# Altium schematic sheet Energy Harvesting.SchDoc("Energy Harvesting")
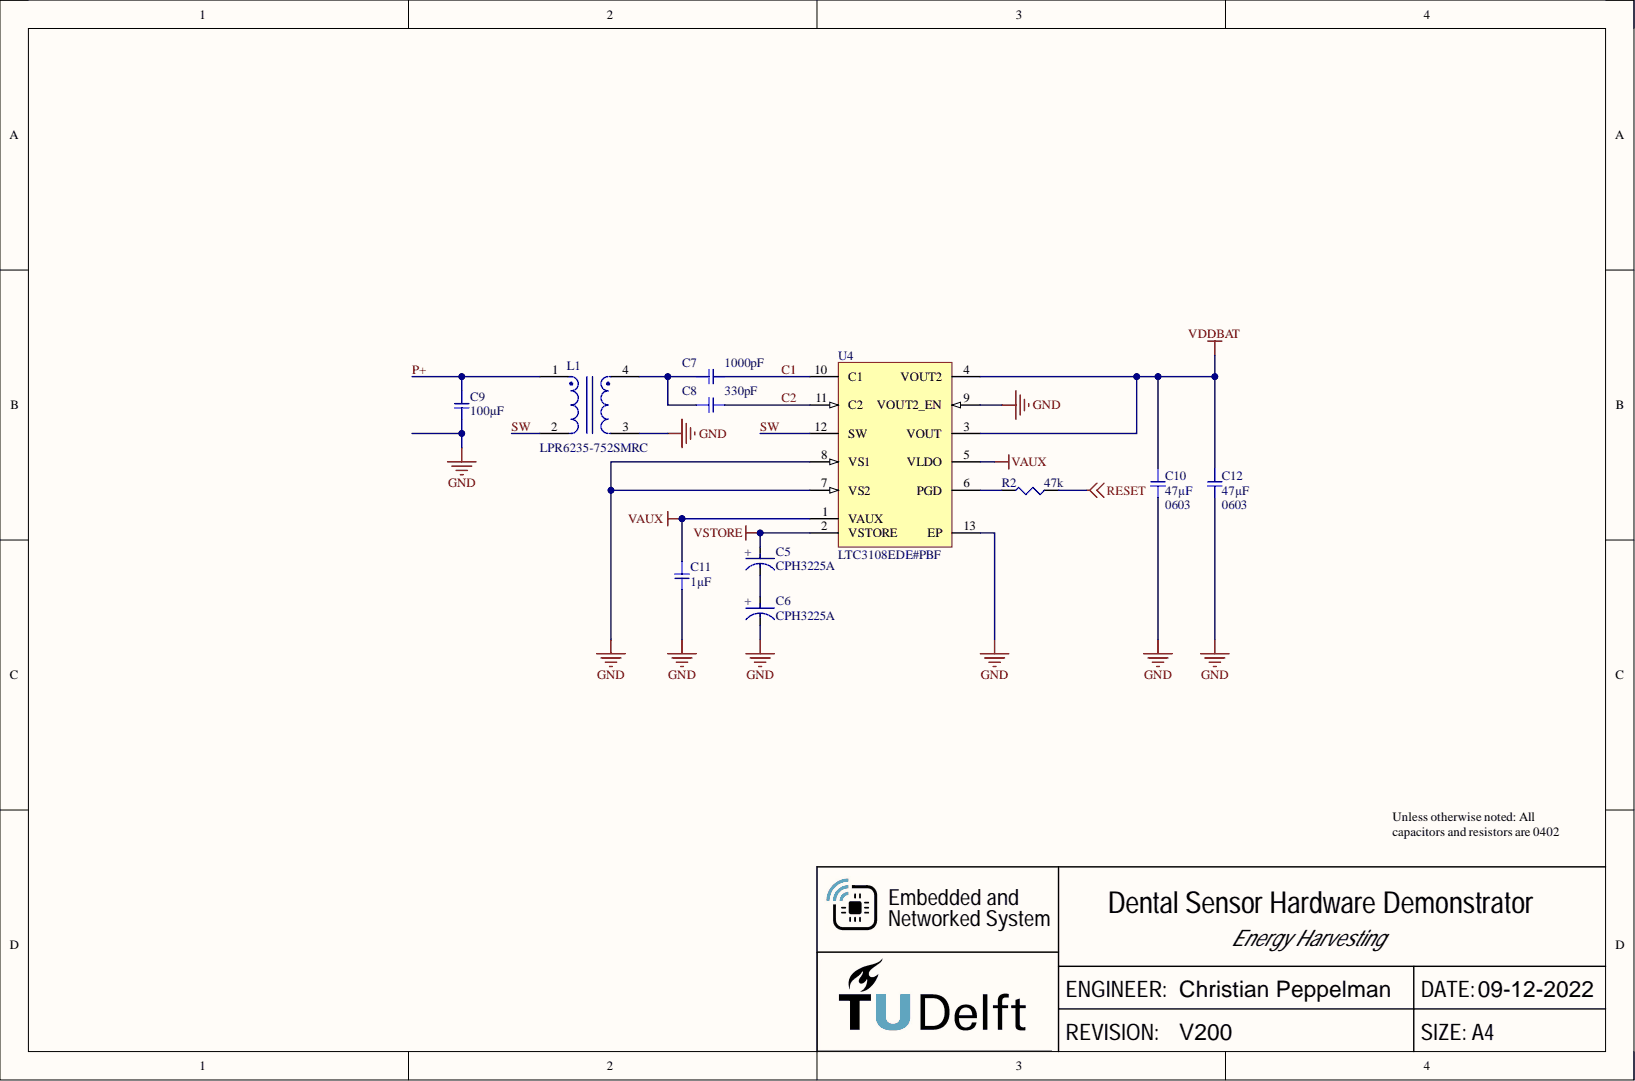
[diagram p0: Energy Harvesting.SchDoc]

## Parts
C5  CPH3225A  [CPH3225A]
C6  CPH3225A  [CPH3225A]
C7  1000pF  [CAPC1005X03N]
C8  330pF  [CAPC1005X03N]
C9  100µF  [CAPC2012X12N]
C10  47µF  Package / Case: 0603  [CAPC1608X12N]
C11  1µF  [CAPC1005X03N]
C12  47µF  Package / Case: 0603  [CAPC1608X12N]
L1  LPR6235-752SMRC  [LPR6235]
R2  47k  [CAPC1005X03N]
U4  LTC3108EDE#PBF  [LT-DE-12-05-08-1708_V]

## Nets
GND: C6.2 C9.2 C10.2 C11.2 C12.2 L1.3 U4.7(VS2) U4.8(VS1) U4.9(VOUT2_EN) U4.13(EP)
RESET: R2.1
VAUX: C11.1 U4.1(VAUX) U4.5(VLDO)
VDDBAT: C10.1 C12.1 U4.3(VOUT) U4.4(VOUT2)
VSTORE: C5.1 U4.2(VSTORE)
C1: C7.2 U4.10(C1)
C2: C8.2 U4.11(C2)
P+: C9.1 L1.1
SW: L1.2 U4.12(SW)
NetC5_2: C5.2 C6.1
NetC7_1: C7.1 C8.1 L1.4
NetR2_2: R2.2 U4.6(PGD)

## Library data of the parts
C5 C6 = CPH3225A: Supercapacitors / Ultracapacitors Reflow EDLC 3.3V, 11mF, 160 Ohm; Component Kind: Standard; Library Name: Library.SchLib
C7 = GRM155R61C102KA01D: CAP CER 1000PF 16V X5R 0402; Manufacturer: Murata Electronics North America; @ qty: 0; Minimum Quantity: 1; Tolerance: ±10%; Unit Price (USD): 0.1; Applications: General Purpose; Component Kind: Standard; Datasheets: http://www.murata.com/~/media/webrenewal/support/library/catalog/products/capacitor/mlcc/c02e.pdf; Digi-Key Part Number: 490-6308-1-ND; Factory Stock: 0; Features: -; Height - Seated (Max): -; Image: //media.digikey.com/Renders/Murata%20Renders/ZRB-SERIES-0402.jpg; Lead Spacing: -; Library Name: capacitor_murata_0402.IntLib; Mounting Type: Surface Mount, MLCC; Operating Temperature: -55°C ~ 85°C; Package / Case: 0402 (1005 Metric); Packaging: Cut Tape (CT); Part Number: GRM155R61C102KA01D; Part Status: Active; Quantity Available: 57479; Ratings: -; Series: GRM; Size / Dimension: 0.039" L x 0.020" W (1.00mm x 0.50mm); Temperature Coefficient: X5R; Thickness (Max): 0.022" (0.55mm); Value: 1000pF; Voltage: 16V
C8 = GRM155R71H331KA01J: CAP CER 330PF 50V X7R 0402; Manufacturer: Murata Electronics North America; @ qty: 0; Minimum Quantity: 1; Tolerance: ±10%; Unit Price (USD): 0.1; Applications: General Purpose; Component Kind: Standard; Datasheets: http://www.murata.com/~/media/webrenewal/support/library/catalog/products/capacitor/mlcc/c02e.pdf; Digi-Key Part Number: 490-11424-1-ND; Factory Stock: 0; Features: -; Height - Seated (Max): -; Image: //media.digikey.com/Renders/Murata%20Renders/ZRB-SERIES-0402.jpg; Lead Spacing: -; Lead Style: -; Library Name: capacitor_murata_0402.IntLib; Mounting Type: Surface Mount, MLCC; Operating Temperature: -55°C ~ 125°C; Package / Case: 0402 (1005 Metric); Packaging: Cut Tape (CT); Part Number: GRM155R71H331KA01J; Part Status: Active; Quantity Available: 47870; Ratings: -; Series: GRM; Size / Dimension: 0.039" L x 0.020" W (1.00mm x 0.50mm); Temperature Coefficient: X7R; Thickness (Max): 0.022" (0.55mm); Value: 330pF; Voltage: 50V
C9 = GRM21BC80E107ME15L: CAP CER 100UF 2.5V X6S 0805; Manufacturer: Murata Electronics North America; @ qty: 0; Minimum Quantity: 1; Tolerance: ±20%; Unit Price (USD): 2.17; Applications: General Purpose; Component Kind: Standard; Datasheets: http://search.murata.co.jp/Ceramy/image/img/A01X/G101/ENG/GRM21BC80E107ME15-01.pdf; Digi-Key Part Number: 490-13977-1-ND; Factory Stock: 0; Features: -; Height - Seated (Max): -; Image: //media.digikey.com/Renders/Murata%20Renders/0805%20(2012%20Metric)%20.85mm.jpg; Lead Spacing: -; Lead Style: -; Library Name: capacitor_murata_0805.IntLib; Mounting Type: Surface Mount, MLCC; Operating Temperature: -55°C ~ 105°C; Package / Case: 0805 (2012 Metric); Packaging: Cut Tape (CT); Part Number: GRM21BC80E107ME15L; Part Status: Active; Quantity Available: 5990; Ratings: -; Series: GRM; Size / Dimension: 0.079" L x 0.049" W (2.00mm x 1.25mm); Temperature Coefficient: X6S; Thickness (Max): 0.057" (1.45mm); Value: 100µF; Voltage: 2.5V
C10 C12 = GRJ155R60J106ME11D: CAP CER 47UF 6.3V X5R 0603; Manufacturer: Murata Electronics North America; @ qty: 0; Minimum Quantity: 1; Tolerance: ±20%; Unit Price (USD): 0.23; Applications: Boardflex Sensitive; Component Kind: Standard; Datasheets: http://psearch.en.murata.com/capacitor/product/GRJ155R60J106ME11%23.pdf; Digi-Key Part Number: 490-13211-1-ND; Factory Stock: 0; Features: Derating Recommended, Soft Termination; Height - Seated (Max): -; Image: //media.digikey.com/Renders/Murata%20Renders/ZRB-SERIES-0402.jpg; Lead Spacing: -; Lead Style: -; Library Name: capacitor_murata_0402.IntLib; Mounting Type: Surface Mount, MLCC; Operating Temperature: -55°C ~ 85°C; Packaging: Cut Tape (CT); Part Number: GRJ155R60J106ME11D; Part Status: Active; Quantity Available: 64064; Ratings: -; Series: GRJ; Size / Dimension: 0.039" L x 0.020" W (1.00mm x 0.50mm); Temperature Coefficient: X5R; Thickness (Max): 0.028" (0.70mm); Value: 47µF; Voltage: 6.3V
C11 = GRT155R61C105KE01D: CAP CER 1UF 16V X5R 0402; Manufacturer: Murata Electronics North America; @ qty: 0; Minimum Quantity: 1; Tolerance: ±10%; Unit Price (USD): 0.1; Applications: Automotive; Component Kind: Standard; Datasheets: http://search.murata.co.jp/Ceramy/image/img/A01X/G101/ENG/GRT155R61C105KE01-01.pdf; Digi-Key Part Number: 490-12255-1-ND; Factory Stock: 0; Features: -; Height - Seated (Max): -; Image: //media.digikey.com/Renders/Murata%20Renders/ZRB-SERIES-0402.jpg; Lead Spacing: -; Lead Style: -; Library Name: capacitor_murata_0402.IntLib; Mounting Type: Surface Mount, MLCC; Operating Temperature: -55°C ~ 85°C; Package / Case: 0402 (1005 Metric); Packaging: Cut Tape (CT); Part Number: GRT155R61C105KE01D; Part Status: Active; Quantity Available: 10000; Ratings: AEC-Q200; Series: GRT; Size / Dimension: 0.039" L x 0.020" W (1.00mm x 0.50mm); Temperature Coefficient: X5R; Thickness (Max): 0.022" (0.55mm); Value: 1µF; Voltage: 16V
L1 = LPR6235-752SMRC: Gekoppelde smoorspoelen 7.5uH 75% 1.6A 316 Ohms 1:100; Component Kind: Standard; Library Name: Library.SchLib
R2 = ERJ-2RKF4702X: RES SMD 47K OHM 1% 1/10W 0402; Manufacturer: Panasonic Electronic Components; @ qty: 1500; Factory Stock: 0; Minimum Quantity: 1; Power (Watts): 0.1W, 1/10W; Temperature Coefficient: ±100ppm/°C; Unit Price (USD): 0.00496; Component Kind: Standard; Composition: Thick Film; Datasheets: http://industrial.panasonic.com/www-cgi/jvcr13pz.cgi?E+PZ+3+AOA0002+ERJ2RKF4702X+7+WW; Digi-Key Part Number: P47.0KLCT-ND; Failure Rate: -; Features: Automotive AEC-Q200; Height - Seated (Max): 0.016" (0.40mm); Image: //media.digikey.com/Photos/Panasonic%20Photos/ERJ-PA2-Series.jpg; Library Name: Panasonic_ERJ_0402.IntLib; Number of Terminations: 2; Operating Temperature: -55°C ~ 155°C; Package / Case: 0402 (1005 Metric); Packaging: Cut Tape (CT); Part Number: ERJ-2RKF4702X; Part Status: Active; Quantity Available: 230515; Series: ERJ; Size / Dimension: 0.039" L x 0.020" W (1.00mm x 0.50mm); Supplier Device Package: 0402; Tolerance: ±1%; Value: 47k
U4 = CMP-0473-00079-1: Ultralow Voltage Step-Up Converter and Power Manager, 12-pin SON (DE-12), -40 to 125 degC, Pb-Free; Manufacturer: Linear Technology; Energy Source: Thermoelectric; Number of Outputs: 3; Topology: Boost, LDO; Vin Max(V): 0.5; Vin Min(V): 0.02; Vout Max(V): 5; Code_JEDEC: MO-229; Component Kind: Standard; ComponentLink1Description: Manufacturer URL; ComponentLink1URL: http://www.linear.com/; ComponentLink2Description: Datasheet; ComponentLink2URL: http://cds.linear.com/docs/en/datasheet/3108fb.pdf; ComponentLink3Description: Package Specification; ComponentLink3URL: http://cds.linear.com/docs/en/datasheet/3473f.pdf; DatasheetVersion: Rev. B; Library Name: Altium Content Vault; Mounting Technology: Surface Mount; PackageDescription: 12-Pin Plastic DFN, Body 4 x 3 mm, Pitch 0.5 mm; PackageReference: DE-12; PartNumber: LTC3108EDE#PBF; RoHS: TRUE
